# Altium schematic sheet General Overview.SchDoc
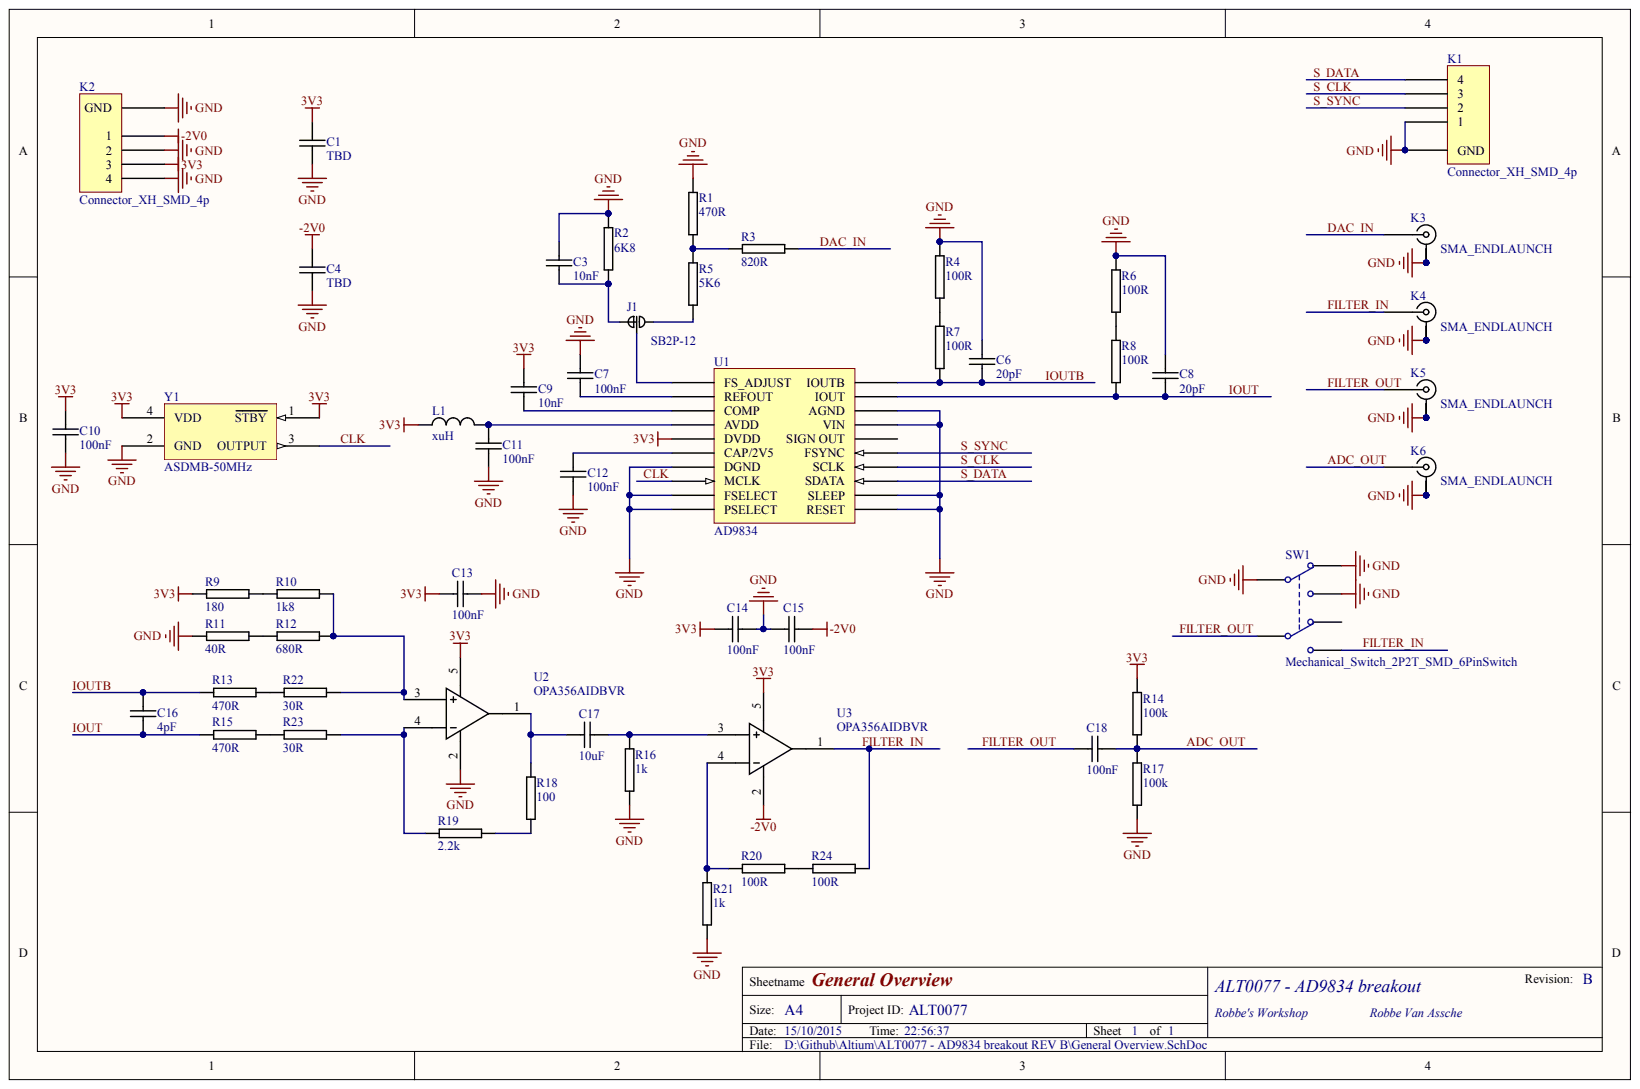
[diagram p0: General Overview.SchDoc]

## Parts
C1  TBD  [1210C-GENERIC]
C3  10nF  [0603C-GENERIC]
C4  TBD  [1210C-GENERIC]
C6  20pF  [0603C-GENERIC]
C7  100nF  [0603C-GENERIC]
C8  20pF  [0603C-GENERIC]
C9  10nF  [0603C-GENERIC]
C10  100nF  [0603C-GENERIC]
C11  100nF  [0603C-GENERIC]
C12  100nF  [0603C-GENERIC]
C13  100nF  [0603C-GENERIC]
C14  100nF  [0603C-GENERIC]
C15  100nF  [0603C-GENERIC]
C16  4pF  [0603C-GENERIC]
C17  10uF  [0603C-GENERIC]
C18  100nF  [0603C-GENERIC]
J1  SB2P-12  [Connector_Production Connectors_SMD_Solderbridge2P - 12]
K1  Connector_XH_SMD_4p  [2.54_4p]
K2  Connector_XH_SMD_4p  [2.54_4p]
K3  SMA_ENDLAUNCH  [SMA_ENDLAUNCH]
K4  SMA_ENDLAUNCH  [SMA_ENDLAUNCH]
K5  SMA_ENDLAUNCH  [SMA_ENDLAUNCH]
K6  SMA_ENDLAUNCH  [SMA_ENDLAUNCH]
L1  xuH  [L0402]
R1  470R  [0603R-470]
R2  6K8  [0603R-GENERIC]
R3  820R  [0603R-820]
R4  100R  [0603R-100]
R5  5K6  [0603R-GENERIC]
R6  100R  [0603R-100]
R7  100R  [0603R-100]
R8  100R  [0603R-100]
R9  180  [0603R-820]
R10  1k8  [0603R-820]
R11  40R  [0603R-820]
R12  680R  [0603R-820]
R13  470R  [0603R-820]
R14  100k  [0603R-820]
R15  470R  [0603R-820]
R16  1k  [0603R-820]
R17  100k  [0603R-820]
R18  100  [0603R-820]
R19  2.2k  [0603R-820]
R20  100R  [0603R-820]
R21  1k  [0603R-820]
R22  30R  [0603R-820]
R23  30R  [0603R-820]
R24  100R  [0603R-820]
SW1  Mechanical_Switch_2P2T_SMD_6PinSwitch  [2P2T_9x4_6_PIN]
U1  AD9834  [AD_TSSOP20]
U2  OPA356AIDBVR  [TI_SOT23-5]
U3  OPA356AIDBVR  [TI_SOT23-5]
Y1  ASDMB-50MHz  [ABRA_ASDMB]

## Nets
3V3: C1.1 C9.2 C10.1 C13.1 C14.1 K2.3 L1.1 R9.1 R14.2 U1.5(DVDD) U2.5 U3.5 Y1.1(STBY) Y1.4(VDD)
-2V0: C4.1 C15.2 K2.1 U3.2
GND: C1.2 C3.1 C4.2 C6.1 C7.1 C8.1 C10.2 C11.2 C12.2 C13.2 C14.2 C15.1 K1.0(GND) K1.1 K2.0(GND) K2.2 K2.4 K3.2 K3.3 K3.4 K3.5 K4.2 K4.3 K4.4 K4.5 K5.2 K5.3 K5.4 K5.5 K6.2 K6.3 K6.4 K6.5 R1.1 R2.1 R4.1 R6.1 R11.1 R16.2 R17.1 R21.1 SW1.1 SW1.2 SW1.3 U1.7(DGND) U1.9(FSELECT) U1.10(PSELECT) U1.11(RESET) U1.12(SLEEP) U1.17(VIN) U1.18(AGND) U2.2 Y1.2(GND)
ADC_OUT: C18.2 K6.1 R14.1 R17.2
CLK: U1.8(MCLK) Y1.3(OUTPUT)
DAC_IN: K3.1 R3.2
FILTER_IN: K4.1 R24.2 SW1.6 U3.1
FILTER_OUT: C18.1 K5.1 SW1.5
IOUT: C8.2 C16.2 R8.2 R15.1 U1.19(IOUT)
IOUTB: C6.2 C16.1 R7.2 R13.1 U1.20(IOUTB)
S_CLK: K1.3 U1.14(SCLK)
S_DATA: K1.4 U1.13(SDATA)
S_SYNC: K1.2 U1.15(FSYNC)
NetC3_2: C3.2 J1.2 R2.2
NetC7_2: C7.2 U1.2(REFOUT)
NetC9_1: C9.1 U1.3(COMP)
NetC11_1: C11.1 L1.2 U1.4(AVDD)
NetC12_1: C12.1 U1.6(CAP/2V5)
NetC17_1: C17.1 R18.2 U2.1
NetC17_2: C17.2 R16.1 U3.3
NetJ1_1: J1.1 U1.1(FS_ADJUST)
NetJ1_3: J1.3 R5.2
NetR1_2: R1.2 R3.1 R5.1
NetR4_2: R4.2 R7.1
NetR6_2: R6.2 R8.1
NetR9_2: R9.2 R10.1
NetR10_2: R10.2 R12.2 R22.2 U2.3
NetR11_2: R11.2 R12.1
NetR13_2: R13.2 R22.1
NetR15_2: R15.2 R23.1
NetR18_1: R18.1 R19.2
NetR19_1: R19.1 R23.2 U2.4
NetR20_1: R20.1 R21.2 U3.4
NetR20_2: R20.2 R24.1

Not connected: SW1 4; U1 16(SIGN_OUT)

## Library data of the parts
C1 C4 = Passives_Capacitors_1210_1210C-TBD: CAPACITOR 1210 CERAMIC VALUE TO BE DETERMINED; Component Kind: Standard; Library Name: RVA_Passives_Capacitors_1210.SchLib
C3 C7 C9-C15 C17 C18 = Passives_Capacitors_0603_000: CAPACITOR 0603 CERAMIC; Component Kind: Standard; Library Name: RVA_Passives_Capacitors_0603.SchLib
C6 C8 C16 = Passives_Capacitors_0603_22PF-50V-C0G-PAN: CAPACITOR 0603 CERAMIC; Manufacturer: Panasonic - ECG; Manufacturer Part Number: ECJ-1VC1H220J; Capacitance: 22pF; Reel: C10; Temperature Coefficient: C0G, NP0; Tolerance: ±5%; Voltage - Rated: 50V; Category: Capacitors; Component Kind: Standard; Features: General Purpose; Lead Spacing: -; Mounting Type: Surface Mount, MLCC; Operating Temperature: -55°C ~ 125°C; Package / Case: 0603 (1608 metric); Packaging: Tape & Reel (TR); RoHS: RoHS Compliant; Supplier: Digi-Key; Supplier 1: Digi-Key; Supplier Part Number: PCC220ACVTR-ND; Supplier Part Number 1: PCC220ACVTR-ND; Thickness: 0.80mm; Library Name: RVA_Passives_Capacitors_0603.SchLib
J1 = Connector_Production Connectors_SMD_SB2P-12: Component Kind: Net Tie; Library Name: RVA_Connector_Production Connectors_SMD.SchLib
K3-K6 = RVA_Connector_RF_SMD_SMA-EL: SMA_EL; Component Kind: Standard; Library Name: RVA_Connector_RF_SMD.SchLib
L1 = Passives_Inductors_0402_TBD: Component Kind: Standard; Library Name: RVA_Passives_Inductors_0402.SchLib
R1 = 0603R-470: GENERIC RESISTOR 0603; Manufacturer: Stackpole Electronics Inc; Manufacturer Part Number: RMCF 1/16 470 1% R; Power (Watts): 0.1W, 1/10W; Reel: R17; Resistance In Ohms: 470; Temperature Coefficient: ±100ppm/°C; Tolerance: ±1%; Case: 0603 (1608 metric); Category: Resistors; Component Kind: Standard; Composition: Thick Film; Lead Style: Surface Mount (SMD - SMT); Packaging: Tape & Reel (TR); RoHS: RoHS Compliant; Supplier: Digi-Key; Supplier 1: Digi-Key; Supplier Part Number: RMCF1/16470FRTR-ND; Supplier Part Number 1: RMCF1/16470FRTR-ND; Library Name: RVA_Passives_Resistors_0603.SchLib
R2 = 0603R-6K8: GENERIC RESISTOR 0603; Manufacturer: Stackpole Electronics Inc; Manufacturer Part Number: RMCF 1/16 6.8K 1% R; Power (Watts): 0.1W, 1/10W; Resistance In Ohms: 6.80K; Temperature Coefficient: ±100ppm/°C; Tolerance: ±1%; Case: 0603 (1608 metric); Category: Resistors; Component Kind: Standard; Composition: Thick Film; Lead Style: Surface Mount (SMD - SMT); Packaging: Tape & Reel (TR); RoHS: RoHS Compliant; Supplier: Digi-Key; Supplier 1: Digi-Key; Supplier Part Number: RMCF1/166.8KFRTR-ND; Supplier Part Number 1: RMCF1/166.8KFRTR-ND; Library Name: RVA_Passives_Resistors_0603.SchLib
R3 R9-R24 = Passives_Resistors_0603_820: GENERIC RESISTOR 0603; Manufacturer: Stackpole Electronics Inc; Manufacturer Part Number: RMC 1/16 820 1% R; Power (Watts): 0.1W, 1/10W; Resistance In Ohms: 820; Temperature Coefficient: ±100ppm/°C; Tolerance: ±1%; Case: 0603 (1608 metric); Category: Resistors; Component Kind: Standard; Composition: Thick Film; Lead Style: Surface Mount (SMD - SMT); Packaging: Tape & Reel (TR); RoHS: RoHS non-compliant; Supplier: Digi-Key; Supplier 1: Digi-Key; Supplier Part Number: RMC1/16820FR-ND; Supplier Part Number 1: RMC1/16820FR-ND; Library Name: RVA_Passives_Resistors_0603.SchLib
R4 R6-R8 = 0603R-100: GENERIC RESISTOR 0603; Manufacturer: Stackpole Electronics Inc; Manufacturer Part Number: RMCF 1/16 100 1% R; Reel: R24; Component Kind: Standard; Supplier 1: Digi-Key; Supplier Part Number 1: RMCF1/16100FRDKR-ND; Library Name: RVA_Passives_Resistors_0603.SchLib
R5 = 0603R-5K62: GENERIC RESISTOR 0603; REEL: R39; Component Kind: Standard; Supplier 1: Digi-Key; Supplier Part Number 1: RMCF0603FT5K62TR-ND; Library Name: RVA_Passives_Resistors_0603.SchLib
U1 = RVA_Interface_DDS_SMD_AD9834: 75MHz Complete DDS; Component Kind: Standard; Library Name: RVA_Interface_DDS_SMD.SchLib
U2 U3 = RVA_Opamp_SMD_OPA356AIDBVR: 200MHz, CMOS OPAMP; Component Kind: Standard; Library Name: RVA_Opamp_SMD.SchLib
Y1 = RVA_Reference_Crystal Oscillator_SMD_ASDMB: Component Kind: Standard; Library Name: RVA_Reference_Crystal Oscillator_SMD.SchLib
K1 K2 = Connector_XH_SMD_4p: Component Kind: Standard; Library Name: RVA_Connector_XH_SMD.SchLib
SW1 = Mechanical_Switch_2P2T_SMD_6PinSwitch: Component Kind: Standard; Library Name: RVA_Mechanical_Switch_2P2T_SMD.SchLib
